# Altium schematic sheet 03_Interface.SchDoc
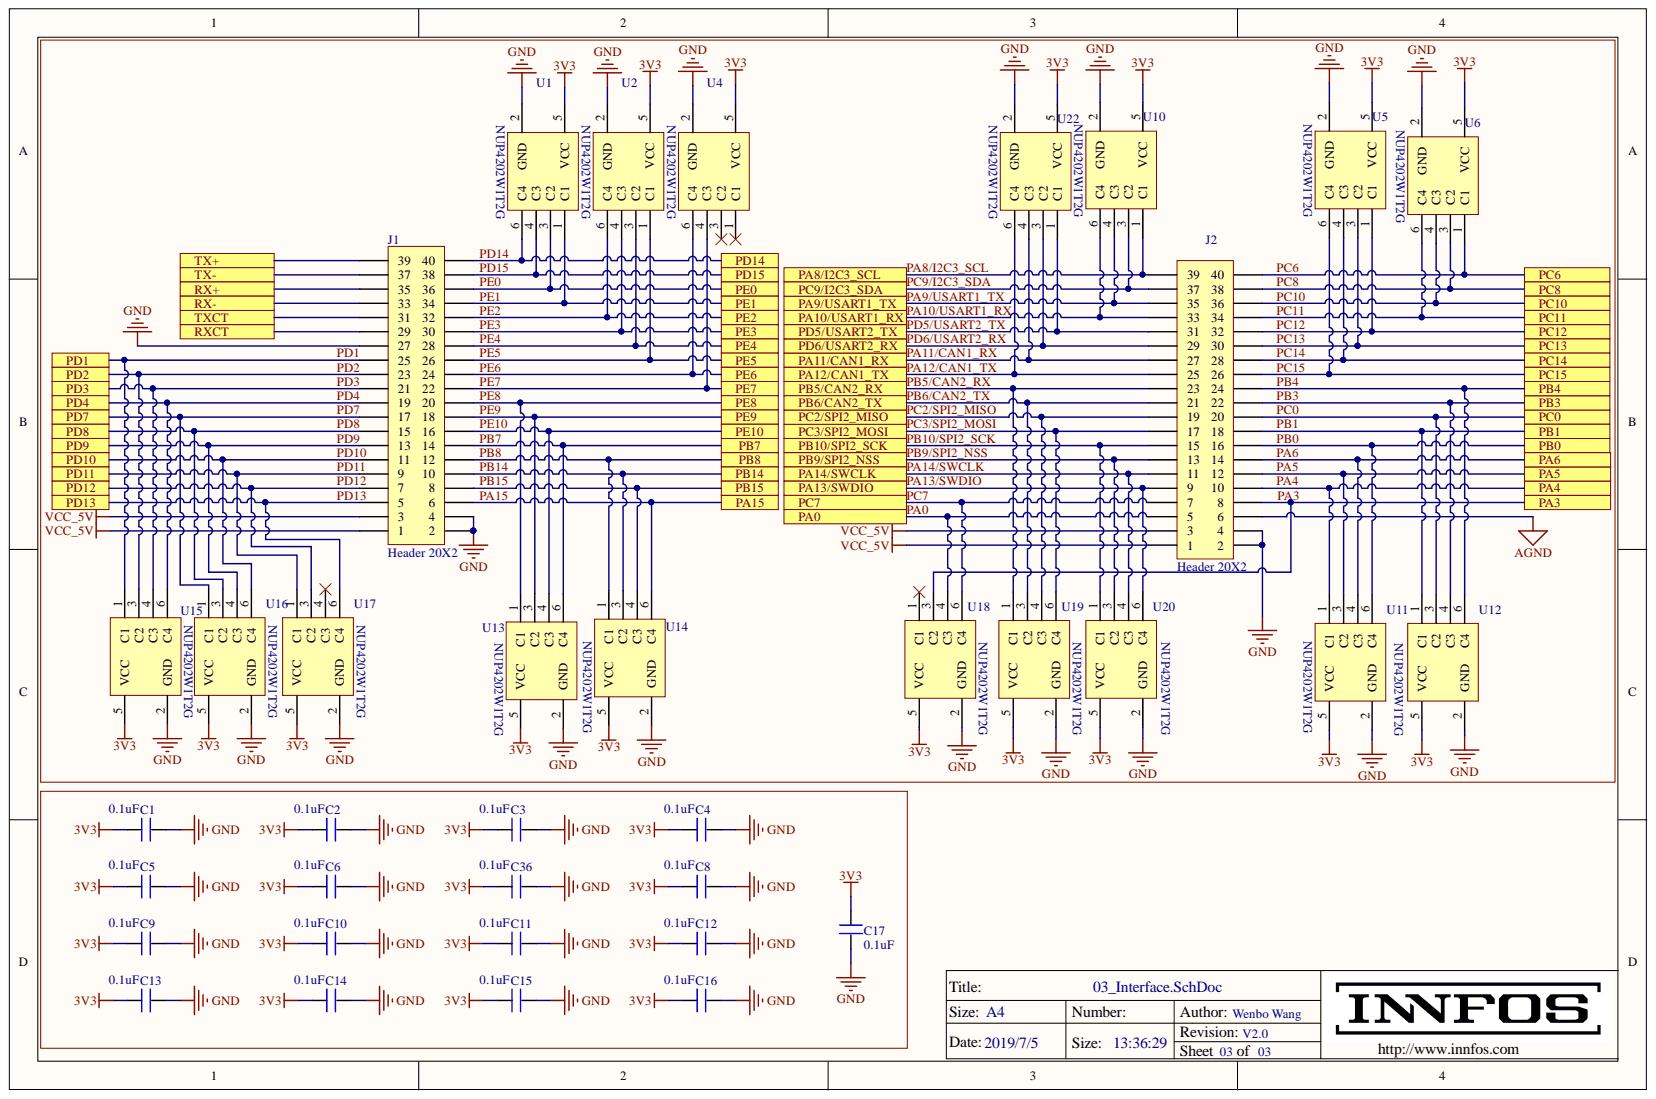
[diagram p0: 03_Interface.SchDoc]

## Parts
C1  0.1uF  [0402]
C2  0.1uF  [0402]
C3  0.1uF  [0402]
C4  0.1uF  [0402]
C5  0.1uF  [0402]
C6  0.1uF  [0402]
C8  0.1uF  [0402]
C9  0.1uF  [0402]
C10  0.1uF  [0402]
C11  0.1uF  [0402]
C12  0.1uF  [0402]
C13  0.1uF  [0402]
C14  0.1uF  [0402]
C15  0.1uF  [0402]
C16  0.1uF  [0402]
C17  0.1uF  [0402]
C36  0.1uF  [0402]
J1  Header 20X2  [0_5_2_20_M]
J2  Header 20X2  [0_5_2_20_M]
U1  NUP4202W1T2G  [TSOP_6]
U2  NUP4202W1T2G  [TSOP_6]
U4  NUP4202W1T2G  [TSOP_6]
U5  NUP4202W1T2G  [TSOP_6]
U6  NUP4202W1T2G  [TSOP_6]
U10  NUP4202W1T2G  [TSOP_6]
U11  NUP4202W1T2G  [TSOP_6]
U12  NUP4202W1T2G  [TSOP_6]
U13  NUP4202W1T2G  [TSOP_6]
U14  NUP4202W1T2G  [TSOP_6]
U15  NUP4202W1T2G  [TSOP_6]
U16  NUP4202W1T2G  [TSOP_6]
U17  NUP4202W1T2G  [TSOP_6]
U18  NUP4202W1T2G  [TSOP_6]
U19  NUP4202W1T2G  [TSOP_6]
U20  NUP4202W1T2G  [TSOP_6]
U22  NUP4202W1T2G  [TSOP_6]

## Ports
PA0, PA3, PA4, PA5, PA6, PA8/I2C3_SCL, PA9/USART1_TX, PA10/USART1_RX, PA11/CAN1_RX, PA12/CAN1_TX, PA13/SWDIO, PA14/SWCLK, PA15, PB0, PB1, PB3, PB4, PB5/CAN2_RX, PB6/CAN2_TX, PB7, PB8, PB9/SPI2_NSS, PB10/SPI2_SCK, PB14, PB15, PC0, PC2/SPI2_MISO, PC3/SPI2_MOSI, PC6, PC7, PC8, PC9/I2C3_SDA, PC10, PC11, PC12, PC13, PC14, PC15, PD1, PD2, PD3, PD4, PD5/USART2_TX, PD6/USART2_RX, PD7, PD8, PD9, PD10, PD11, PD12, PD13, PD14, PD15, PE0, PE1, PE2, PE3, PE4, PE5, PE6, PE7, PE8, PE9, PE10, RX+, RX-, RXCT, TX+, TX-, TXCT

## Nets
3V3: C1.1 C2.1 C3.1 C4.1 C5.1 C6.1 C8.1 C9.1 C10.1 C11.1 C12.1 C13.1 C14.1 C15.1 C16.1 C17.1 C36.1 U1.5(VCC) U2.5(VCC) U4.5(VCC) U5.5(VCC) U6.5(VCC) U10.5(VCC) U11.5(VCC) U12.5(VCC) U13.5(VCC) U14.5(VCC) U15.5(VCC) U16.5(VCC) U17.5(VCC) U18.5(VCC) U19.5(VCC) U20.5(VCC) U22.5(VCC)
AGND: J2.6
GND: C1.2 C2.2 C3.2 C4.2 C5.2 C6.2 C8.2 C9.2 C10.2 C11.2 C12.2 C13.2 C14.2 C15.2 C16.2 C17.2 C36.2 J1.2 J1.4 J1.27 J2.2 J2.4 U1.2(GND) U2.2(GND) U4.2(GND) U5.2(GND) U6.2(GND) U10.2(GND) U11.2(GND) U12.2(GND) U13.2(GND) U14.2(GND) U15.2(GND) U16.2(GND) U17.2(GND) U18.2(GND) U19.2(GND) U20.2(GND) U22.2(GND)
VCC_5V: J1.1 J1.3 J2.1 J2.3
PA0: J2.5 U18.4(C3) ; port PA0
PA3: J2.8 U18.3(C2) ; port PA3
PA4: J2.10 U11.1(C1) ; port PA4
PA5: J2.12 U11.3(C2) ; port PA5
PA6: J2.14 U11.4(C3) ; port PA6
PA8/I2C3_SCL: J2.39 U10.1(C1) ; port PA8/I2C3_SCL
PA9/USART1_TX: J2.35 U10.4(C3) ; port PA9/USART1_TX
PA10/USART1_RX: J2.33 U10.6(C4) ; port PA10/USART1_RX
PA11/CAN1_RX: J2.27 U22.4(C3) ; port PA11/CAN1_RX
PA12/CAN1_TX: J2.25 U22.6(C4) ; port PA12/CAN1_TX
PA13/SWDIO: J2.9 U20.6(C4) ; port PA13/SWDIO
PA14/SWCLK: J2.11 U20.4(C3) ; port PA14/SWCLK
PA15: J1.6 U14.6(C4) ; port PA15
PB0: J2.16 U11.6(C4) ; port PB0
PB1: J2.18 U12.1(C1) ; port PB1
PB3: J2.22 U12.4(C3) ; port PB3
PB4: J2.24 U12.6(C4) ; port PB4
PB5/CAN2_RX: J2.23 U19.1(C1) ; port PB5/CAN2_RX
PB6/CAN2_TX: J2.21 U19.3(C2) ; port PB6/CAN2_TX
PB7: J1.14 U13.6(C4) ; port PB7
PB8: J1.12 U14.1(C1) ; port PB8
PB9/SPI2_NSS: J2.13 U20.3(C2) ; port PB9/SPI2_NSS
PB10/SPI2_SCK: J2.15 U20.1(C1) ; port PB10/SPI2_SCK
PB14: J1.10 U14.3(C2) ; port PB14
PB15: J1.8 U14.4(C3) ; port PB15
PC0: J2.20 U12.3(C2) ; port PC0
PC2/SPI2_MISO: J2.19 U19.4(C3) ; port PC2/SPI2_MISO
PC3/SPI2_MOSI: J2.17 U19.6(C4) ; port PC3/SPI2_MOSI
PC6: J2.40 U6.1(C1) ; port PC6
PC7: J2.7 U18.6(C4) ; port PC7
PC8: J2.38 U6.3(C2) ; port PC8
PC9/I2C3_SDA: J2.37 U10.3(C2) ; port PC9/I2C3_SDA
PC10: J2.36 U6.4(C3) ; port PC10
PC11: J2.34 U6.6(C4) ; port PC11
PC12: J2.32 U5.1(C1) ; port PC12
PC13: J2.30 U5.3(C2) ; port PC13
PC14: J2.28 U5.4(C3) ; port PC14
PC15: J2.26 U5.6(C4) ; port PC15
PD1: J1.25 U15.1(C1) ; port PD1
PD2: J1.23 U15.3(C2) ; port PD2
PD3: J1.21 U15.4(C3) ; port PD3
PD4: J1.19 U15.6(C4) ; port PD4
PD5/USART2_TX: J2.31 U22.1(C1) ; port PD5/USART2_TX
PD6/USART2_RX: J2.29 U22.3(C2) ; port PD6/USART2_RX
PD7: J1.17 U16.1(C1) ; port PD7
PD8: J1.15 U16.3(C2) ; port PD8
PD9: J1.13 U16.4(C3) ; port PD9
PD10: J1.11 U16.6(C4) ; port PD10
PD11: J1.9 U17.1(C1) ; port PD11
PD12: J1.7 U17.3(C2) ; port PD12
PD13: J1.5 U17.6(C4) ; port PD13
PD14: J1.40 U1.6(C4) ; port PD14
PD15: J1.38 U1.4(C3) ; port PD15
PE0: J1.36 U1.3(C2) ; port PE0
PE1: J1.34 U1.1(C1) ; port PE1
PE2: J1.32 U2.6(C4) ; port PE2
PE3: J1.30 U2.4(C3) ; port PE3
PE4: J1.28 U2.3(C2) ; port PE4
PE5: J1.26 U2.1(C1) ; port PE5
PE6: J1.24 U4.6(C4) ; port PE6
PE7: J1.22 U4.4(C3) ; port PE7
PE8: J1.20 U13.1(C1) ; port PE8
PE9: J1.18 U13.3(C2) ; port PE9
PE10: J1.16 U13.4(C3) ; port PE10
RXCT: J1.29 ; port RXCT
TXCT: J1.31 ; port TXCT
NetJ1_33: J1.33
NetJ1_35: J1.35
NetJ1_37: J1.37
NetJ1_39: J1.39

Not connected: U4 1(C1) 3(C2); U17 4(C3); U18 1(C1)

## Library data of the parts
C1-C6 C8-C17 C36 = Cap: Component Kind: Standard; Library Name: My_Schlib.SchLib; Value: 0.1uF
J1 J2 = Header 20X2: Header, 20-Pin, Dual row; Component Kind: Standard; Library Name: Miscellaneous Connectors.IntLib
  J2: LatestRevisionDate: 17-Jul-2002; LatestRevisionNote: Re-released for DXP Platform.; Publisher: Altium Limited
U1 U2 U4-U6 U10-U20 U22 = NUP4201MR6: Component Kind: Standard; Library Name: My_Schlib.SchLib
